# Altium schematic sheet CPLD_Programmer.SchDoc(CPLD_Programmer)
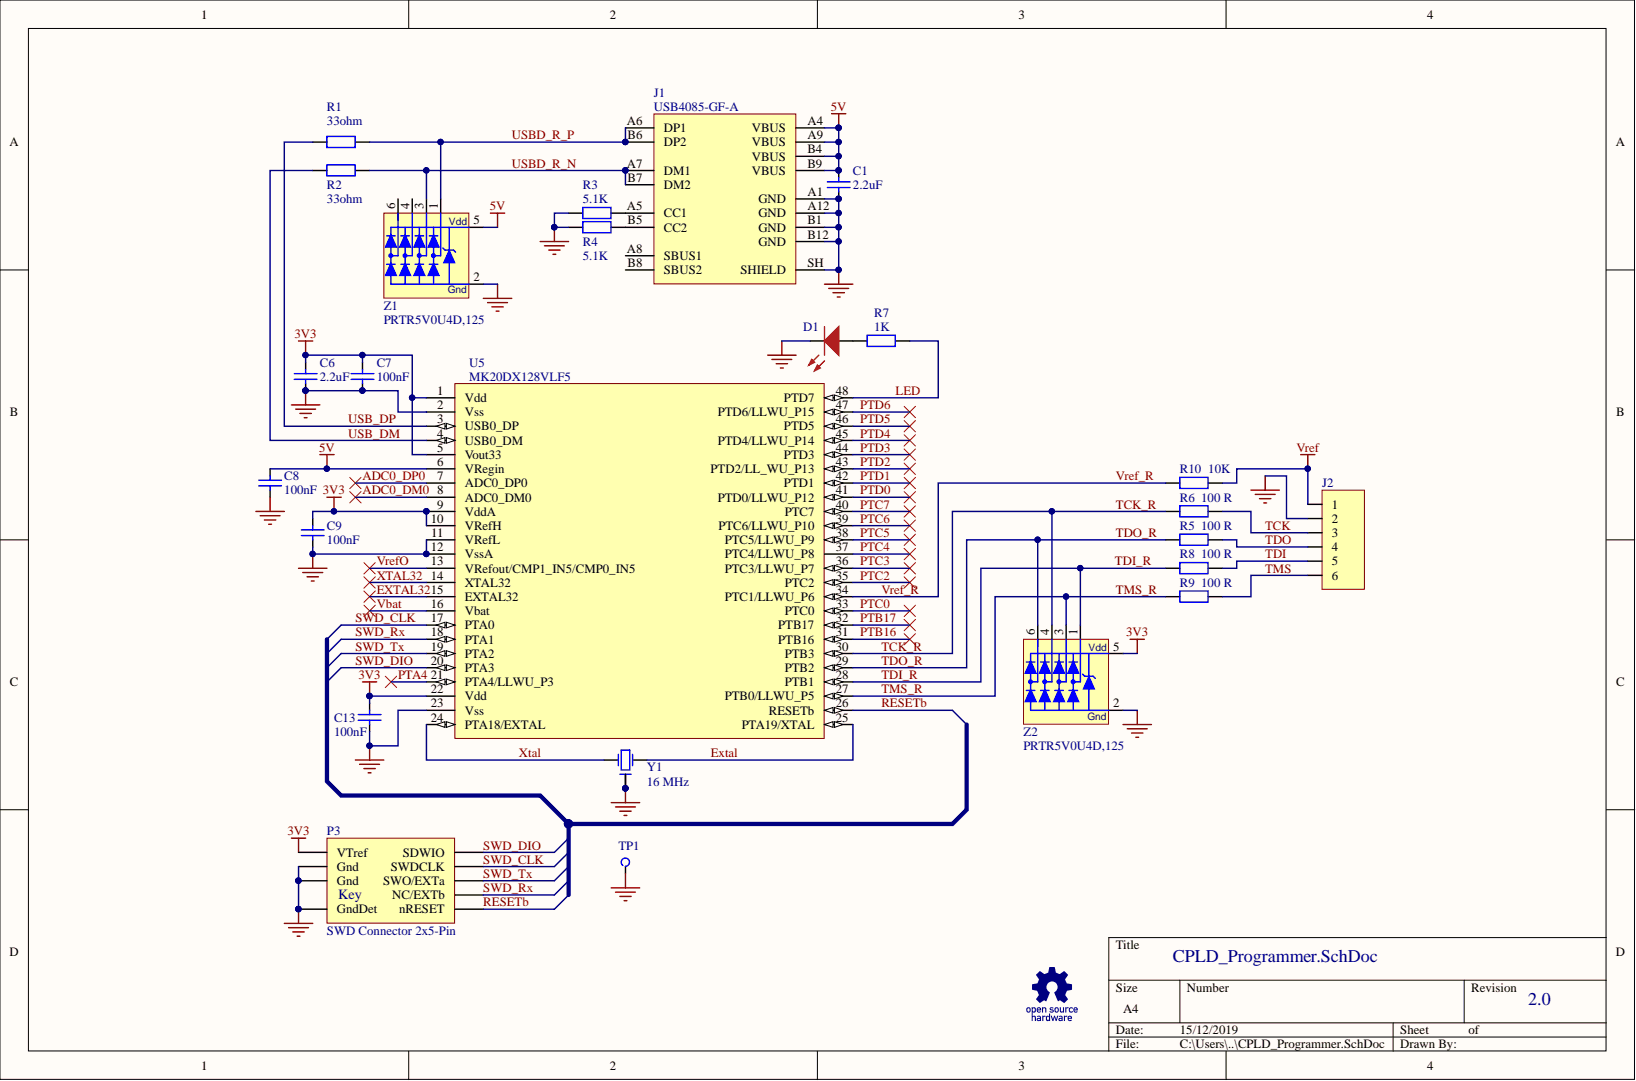
[diagram p0: CPLD_Programmer.SchDoc]

## Parts
C1  2.2uF  [CCNP1608-0603]
C6  2.2uF  [CCNP1608-0603]
C7  100nF  [CCNP1608-0603]
C8  100nF  [CCNP1608-0603]
C9  100nF  [CCNP1608-0603]
C13  100nF  [CCNP1608-0603]
D1  LED Red  [LED1608-0603-RED]  (value not drawn)
J1  USB4085-GF-A  [USB4085-GF-A]
J2  Header, Female, 6-Pin  [HDR_FEM1X6-RA]  (value not drawn)
Logo  OSHW Logo  [OSHW]  (value not drawn)  (no BOM)
P3  SWD Connector 2x5-Pin  [SWD-2x5-Jig-Pads]  (no BOM)
R1  33ohm  [CR1608-0603]
R2  33ohm  [CR1608-0603]
R3  5.1K  [CR1608-0603]
R4  5.1K  [CR1608-0603]
R5  100 R  [CR1608-0603]
R6  100 R  [CR1608-0603]
R7  1K  [CR1608-0603]
R8  100 R  [CR1608-0603]
R9  100 R  [CR1608-0603]
R10  10K  [CR1608-0603]
TP1  TP  [TP]
U5  MK20DX128VLF5  [TSQFP50P900X900-48M]
Y1  16 MHz  [Crystal_SMD_3.2x2.5]
Z1  PRTR5V0U4D,125  [SOT457_N]
Z2  PRTR5V0U4D,125  [SOT457_N]

## Nets
3V3: C6.2 C7.2 C9.2 C13.2 P3.1(VTref) U5.1(Vdd) U5.5(Vout33) U5.9(VddA) U5.10(VRefH) U5.22(Vdd) Z2.5
5V: C1.2 C8.2 J1.A4(VBUS) J1.A9(VBUS) J1.B4(VBUS) J1.B9(VBUS) U5.6(VRegin) Z1.5
GND: C1.1 C6.1 C7.1 C8.1 C9.1 C13.1 D1.K J1.A1(GND) J1.A12(GND) J1.B1(GND) J1.B12(GND) J1.SH(SHIELD) J2.2 P3.3(Gnd) P3.5(Gnd) P3.9(GndDet) R3.2 R4.2 TP1.1 U5.2(Vss) U5.11(VRefL) U5.12(VssA) U5.23(Vss) Y1.2 Y1.4 Z1.2 Z2.2
Vref: J2.1 R10.2
ADC0_DM0: U5.8(ADC0_DM0)
ADC0_DP0: U5.7(ADC0_DP0)
Extal: U5.25(PTA19/XTAL) Y1.3
EXTAL32: U5.15(EXTAL32)
LED: R7.2 U5.48(PTD7)
PTA4: U5.21(PTA4/LLWU_P3)
PTB16: U5.31(PTB16)
PTB17: U5.32(PTB17)
PTC0: U5.33(PTC0)
PTC2: U5.35(PTC2)
PTC3: U5.36(PTC3/LLWU_P7)
PTC4: U5.37(PTC4/LLWU_P8)
PTC5: U5.38(PTC5/LLWU_P9)
PTC6: U5.39(PTC6/LLWU_P10)
PTC7: U5.40(PTC7)
PTD0: U5.41(PTD0/LLWU_P12)
PTD1: U5.42(PTD1)
PTD2: U5.43(PTD2/LL_WU_P13)
PTD3: U5.44(PTD3)
PTD4: U5.45(PTD4/LLWU_P14)
PTD5: U5.46(PTD5)
PTD6: U5.47(PTD6/LLWU_P15)
RESETb: P3.10(nRESET) U5.26(RESETb)
SWD_CLK: P3.4(SWDCLK) U5.17(PTA0)
SWD_DIO: P3.2(SDWIO) U5.20(PTA3)
SWD_Rx: P3.8(NC/EXTb) U5.18(PTA1)
SWD_Tx: P3.6(SWO/EXTa) U5.19(PTA2)
TCK: J2.3 R6.2
TCK_R: R6.1 U5.30(PTB3) Z2.4
TDI: J2.5 R8.2
TDI_R: R8.1 U5.28(PTB1) Z2.1
TDO: J2.4 R5.2
TDO_R: R5.1 U5.29(PTB2) Z2.6
TMS: J2.6 R9.2
TMS_R: R9.1 U5.27(PTB0/LLWU_P5) Z2.3
USB_DM: R2.2 U5.4(USB0_DM)
USB_DP: R1.2 U5.3(USB0_DP)
USBD_R_N: J1.A7(DM1) J1.B7(DM2) R2.1 Z1.3
USBD_R_P: J1.A6(DP1) J1.B6(DP2) R1.1 Z1.1
Vbat: U5.16(Vbat)
Vref_R: R10.1 U5.34(PTC1/LLWU_P6)
VrefO: U5.13(VRefout/CMP1_IN5/CMP0_IN5)
Xtal: U5.24(PTA18/EXTAL) Y1.1
XTAL32: U5.14(XTAL32)
NetD1_A: D1.A R7.1
NetJ1_A5: J1.A5(CC1) R3.1
NetJ1_B5: J1.B5(CC2) R4.1
N00009:

Not connected: J1 A8(SBUS1) B8(SBUS2); Z1 4 6

## Library data of the parts
C1 C6 = Cap_CCNP_0603: Capacitor SMT 0603; Manufacturer: Generic Components; Class: Passive; Value: 2.2uF; SubClass: Capacitor; Simulation: CAP; Signal Integrity: Cap; Published: 08/06/2000; Library Name: MyLibrary.IntLib; LCSC: C23630; Component Kind: Standard; Publisher: Altium Hobart Technology Centre
C7-C9 C13 = Cap: Capacitor; Manufacturer: Generic Components; Class: Passive; Value: 100nF; SubClass: Capacitor; Simulation: CAP; Signal Integrity: Cap; Published: 08/06/2000; Library Name: MyLibrary.IntLib; LCSC: C14663; Component Kind: Standard; Publisher: Altium Hobart Technology Centre
D1 = LED-0603-Red: LED 0603 Red; Manufacturer: Generic Components; Class I: Optoelectronic; Revision: July-2002: Re-released for DXP Platform.; Published: 8-Jun-2000; Library Name: MyLibrary.IntLib; LCSC: C2286; Farnell PN: 1581239; Component Kind: Standard; Class II: LED; Publisher: Altium Limited
J1 = (no symbol name): USB-C, USB 2.0 Receptacle Connector 16 Position Through Hole, Right Angle; Angle: 90; Part Number: USB4085-GF-A; Library Name: MySchLib.schlib; Farnell PN: 2924867; Digikey Part Number: 2073-USB4085-GF-ACT-ND; Digikey Link: https://www.digikey.ee/product-detail/en/gct/USB4085-GF-A/2073-USB4085-GF-ACT-ND/9859733; Digikey: 2073-USB4085-GF-ACT-ND; Component Kind: Standard
J2 = Header Female 1x6S: Signal Integrity: Connector; Library Name: MyLibrary.IntLib; LatestRevisionNote: Re-released for DXP Platform.; LatestRevisionDate: 17-Jul-2002; Description: Header, Female, 6-Pin; Component Kind: Standard; Publisher: Altium Limited
P3 = SWD2X5_JIG: Signal Integrity: Connector; Library Name: MyLibrary.IntLib; LatestRevisionNote: Re-released for DXP Platform.; LatestRevisionDate: 17-Jul-2002; Farnell PN: 1865285; Description: SWD Connector 2x5-Pin; Publisher: Altium Limited
R1-R6 R8-R10 = Res_CR0603: Resistor SMT 0603; Manufacturer: Generic Components; Class: Passive; SubClass: Resistor; Simulation: RESISTOR; Signal Integrity: Res; Published: 08/06/2000; Library Name: MyLibrary.IntLib; Component Kind: Standard; Publisher: Altium Hobart Technology Centre
  R1 R2: Value: 33ohm; LCSC: C23140
  R3 R4: Value: 5.1K; LCSC: C23186
  R5 R6 R8 R9: Value: 100 R; LCSC: C22775
  R10: Value: 10K; LCSC: C25804
R7 = Res: Resistor SMT; Manufacturer: Generic Components; Class: Passive; Value: 1K; SubClass: Resistor; Simulation: RESISTOR; Signal Integrity: Res; Published: 08/06/2000; Library Name: MyLibrary.IntLib; LCSC: C21190; Farnell PN: 1632490; Component Kind: Standard; Publisher: Altium Hobart Technology Centre
TP1 = TP: Test Point; Library Name: MyLibrary.IntLib; Component Kind: Standard
U5 = MK20DX128VLF5: Arm Cortex M4; Library Name: MyLibrary.IntLib; Component Kind: Standard
Y1 = Crystal 16MHz: Crystal SMD 3.2 x 2.5 x 0.7 mm; Manufacturer: Generic Components; SubClass: Crystal Oscillator; Class: Oscillator; Published: 08/06/2000; Library Name: MyLibrary.IntLib; LCSC: C13738; Component Kind: Standard; Publisher: Altium Hobart Technology Centre
Z1 Z2 = PRTR5V0U4D,125: Ultra low capacitance quadruple rail-to-rail ESD protection; Library Name: MySchLib.schlib; LCSC: C96236; Farnell PN: 1757869; Digikey: 1727-3890-1-ND; Component Kind: Standard
Logo = OSHW Logo: Library Name: MySchLib.schlib
